# Altium schematic sheet CH4.SchDoc(CH4)
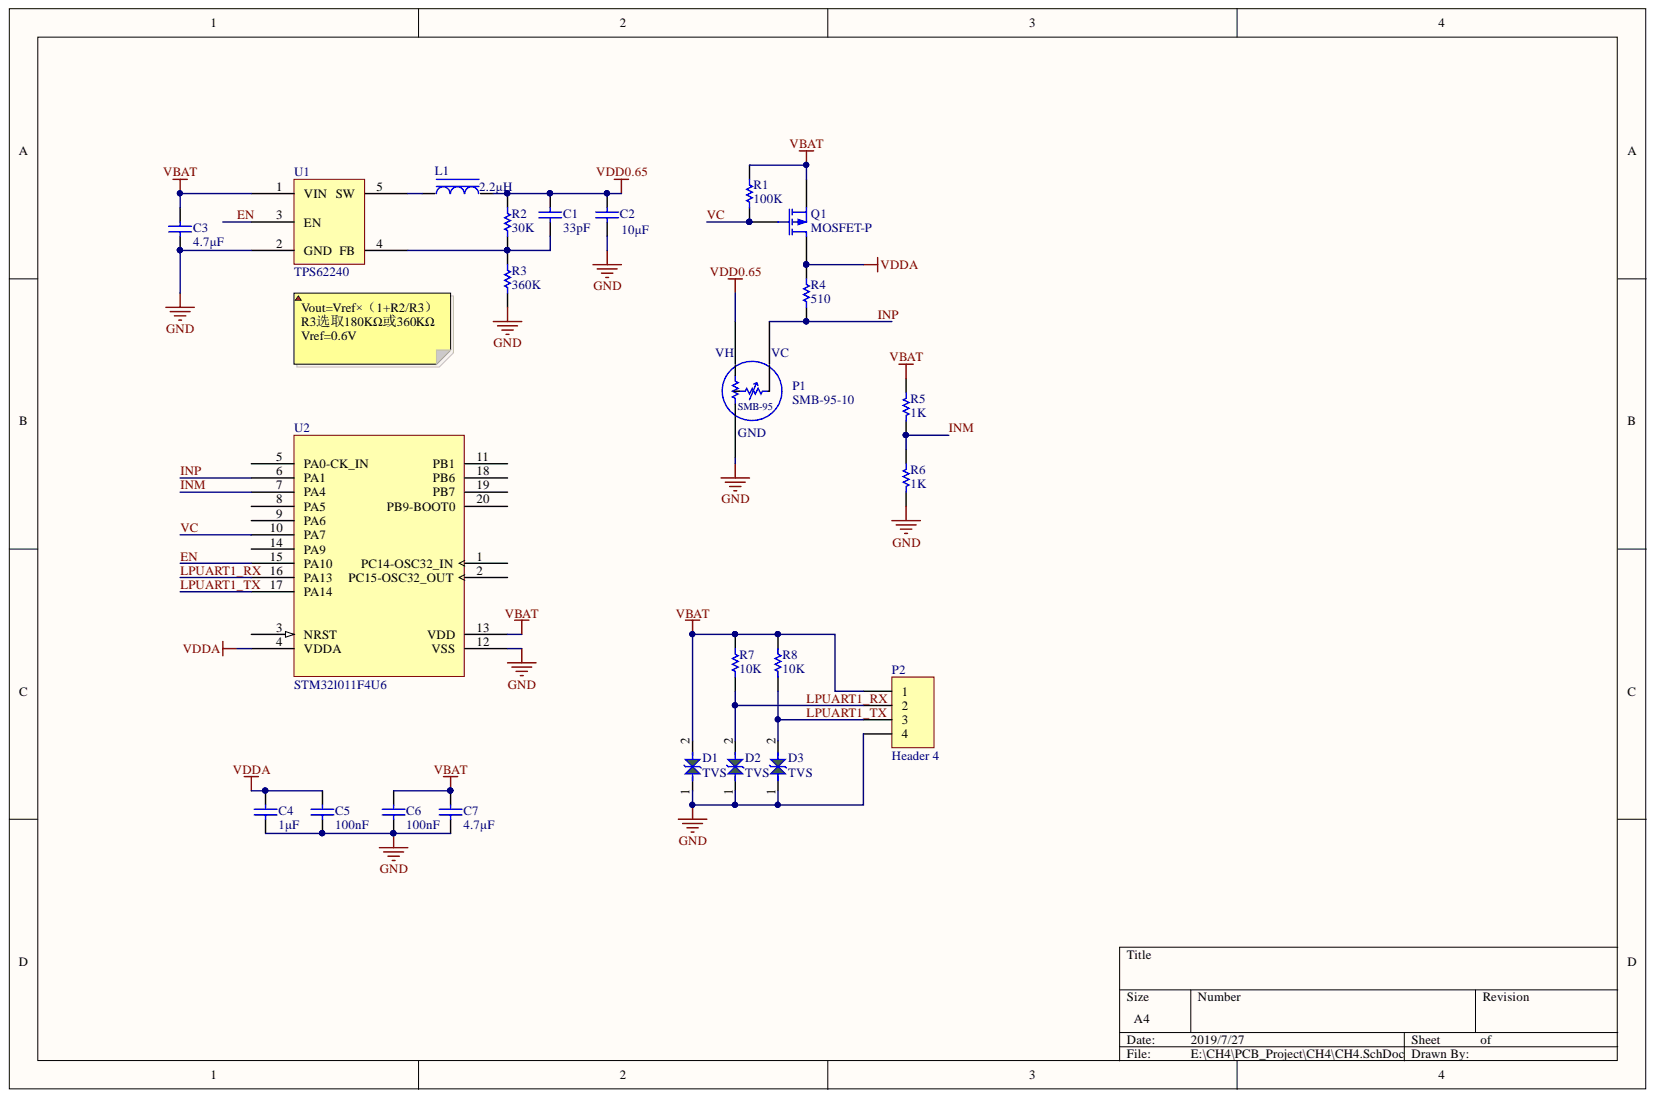
[diagram p0: CH4.SchDoc]

## Parts
C1  33pF  [C-0603]
C2  10μF  [C-0805]
C3  4.7μF  [C-0805]
C4  1μF  [C-0603]
C5  100nF  [C-0603]
C6  100nF  [C-0603]
C7  4.7μF  value 100nF  [C-0603]
D1  TVS  [TVS-0603]
D2  TVS  [TVS-0603]
D3  TVS  [TVS-0603]
L1  2.2μH  [L-2520]
P1  SMB-95-10  [SMB-95-10]
P2  Header 4  [Port-4]
Q1  MOSFET-P  [SOT23]
R1  100K  [R-0603]
R2  30K  [R-0603]
R3  360K  [R-0603]
R4  510  [R-0603]
R5  1K  [R-0603]
R6  1K  [R-0603]
R7  10K  [R-0603]
R8  10K  [R-0603]
U1  TPS62240  [DDC-5]
U2  STM32l011F4U6  [UFQFPN20]

## Nets
GND: C2.1 C3.1 C4.1 C5.1 C6.1 C7.1 D1.1 D2.1 D3.1 P1.1 P2.4 R3.2 R6.2 U1.2(GND) U2.12(VSS)
VBAT: C3.2 C6.2 C7.2 D1.2 P2.1 Q1.2 R1.2 R5.1 R7.1 R8.1 U1.1(VIN) U2.13(VDD)
VDD0.65: C1.2 C2.2 L1.2 P1.3 R2.1
VDDA: C4.2 C5.2 Q1.3 R4.1 U2.4(VDDA)
EN: U1.3(EN) U2.15(PA10)
INM: R5.2 R6.1 U2.7(PA4)
INP: P1.2 R4.2 U2.6(PA1)
LPUART1_RX: D2.2 P2.2 R7.2 U2.16(PA13)
LPUART1_TX: D3.2 P2.3 R8.2 U2.17(PA14)
VC: Q1.1 R1.1 U2.10(PA7)
NetC1_1: C1.1 R2.2 R3.1 U1.4(FB)
NetL1_1: L1.1 U1.5(SW)

Not connected: U2 1(PC14-OSC32_IN) 2(PC15-OSC32_OUT) 3(NRST) 5(PA0-CK_IN) 8(PA5) 9(PA6) 11(PB1) 14(PA9) 18(PB6) 19(PB7) 20(PB9-BOOT0)

## Library data of the parts
C1-C4 = CAP: Capacitor; Value: 100nF; Published: 8-Jun-2000; PackageReference: RAD-0.3; PackageDescription: Radial Cap, Thru-Hole; 2 Leads; 0.3 in Pin Spacing; Library Name: CH4.SchLib; LatestRevisionNote: Re-released for DXP Platform.; LatestRevisionDate: 17-Jul-2002; Component Kind: Standard; Publisher: Altium Limited
C5-C7 = CAP: Capacitor; Published: 8-Jun-2000; PackageReference: RAD-0.3; PackageDescription: Radial Cap, Thru-Hole; 2 Leads; 0.3 in Pin Spacing; Library Name: CH4.SchLib; LatestRevisionNote: Re-released for DXP Platform.; LatestRevisionDate: 17-Jul-2002; Component Kind: Standard; Publisher: Altium Limited
  C5 C6: Value: 100nF
L1 = Inductor: Library Name: CH4.SchLib; Component Kind: Standard
P1 = SMB-95-10: LOW POWER METHANE SENSOR; Library Name: CH4.SchLib; Component Kind: Standard
P2 = Header 4: Header, 4-Pin; Library Name: Miscellaneous Connectors.IntLib; LatestRevisionNote: Re-released for DXP Platform.; LatestRevisionDate: 17-Jul-2002; Component Kind: Standard; Publisher: Altium Limited
Q1 = MOSFET-P: P-Channel MOSFET; Published: 8-Jun-2000; PackageVersion: 1995/1996; PackageReference: E3; PackageDescription: TO, Flat Index; 3 In-Line, Axial Leads; Body Dia. 4.6mm; Lead Dia. 0.5 mm (max); LatestRevisionNote: Re-released for DXP Platform.; LatestRevisionDate: 17-Jul-2002; Component Kind: Standard; Publisher: Altium Limited; Library Name: 微波成像探针控制器.SchLib
R1-R4 R7 R8 = RES: Potentiometer; Value: 1K; Sim Note: Enter the Resistance value and the set point into parameter fields.; Set Position: 0.5; Published: 8-Jun-2000; PackageReference: VR5; PackageDescription: Variable Resistor, Thru-Hole; 3 Leads; Library Name: CH4.SchLib; LatestRevisionNote: Re-released for DXP Platform.; LatestRevisionDate: 17-Jul-2002; Component Kind: Standard; Publisher: Altium Limited
R5 R6 = RES: Potentiometer; Sim Note: Enter the Resistance value and the set point into parameter fields.; Set Position: 0.5; Value: 1K; Published: 8-Jun-2000; PackageReference: VR5; PackageDescription: Variable Resistor, Thru-Hole; 3 Leads; Library Name: CH4.SchLib; LatestRevisionNote: Re-released for DXP Platform.; LatestRevisionDate: 17-Jul-2002; Component Kind: Standard; Publisher: Altium Limited
D1-D3 = TVS: Library Name: CH4.SchLib; Description: TVS; Component Kind: Standard
U1 = TPS62240: Library Name: CH4.SchLib; Description: TPS62240; Component Kind: Standard
U2 = STM32l011F4U6: Library Name: CH4.SchLib; Description: STM32l011F4U6; Component Kind: Standard
